# Altium schematic sheet 04_GAP9_CHIP_MEM.SchDoc
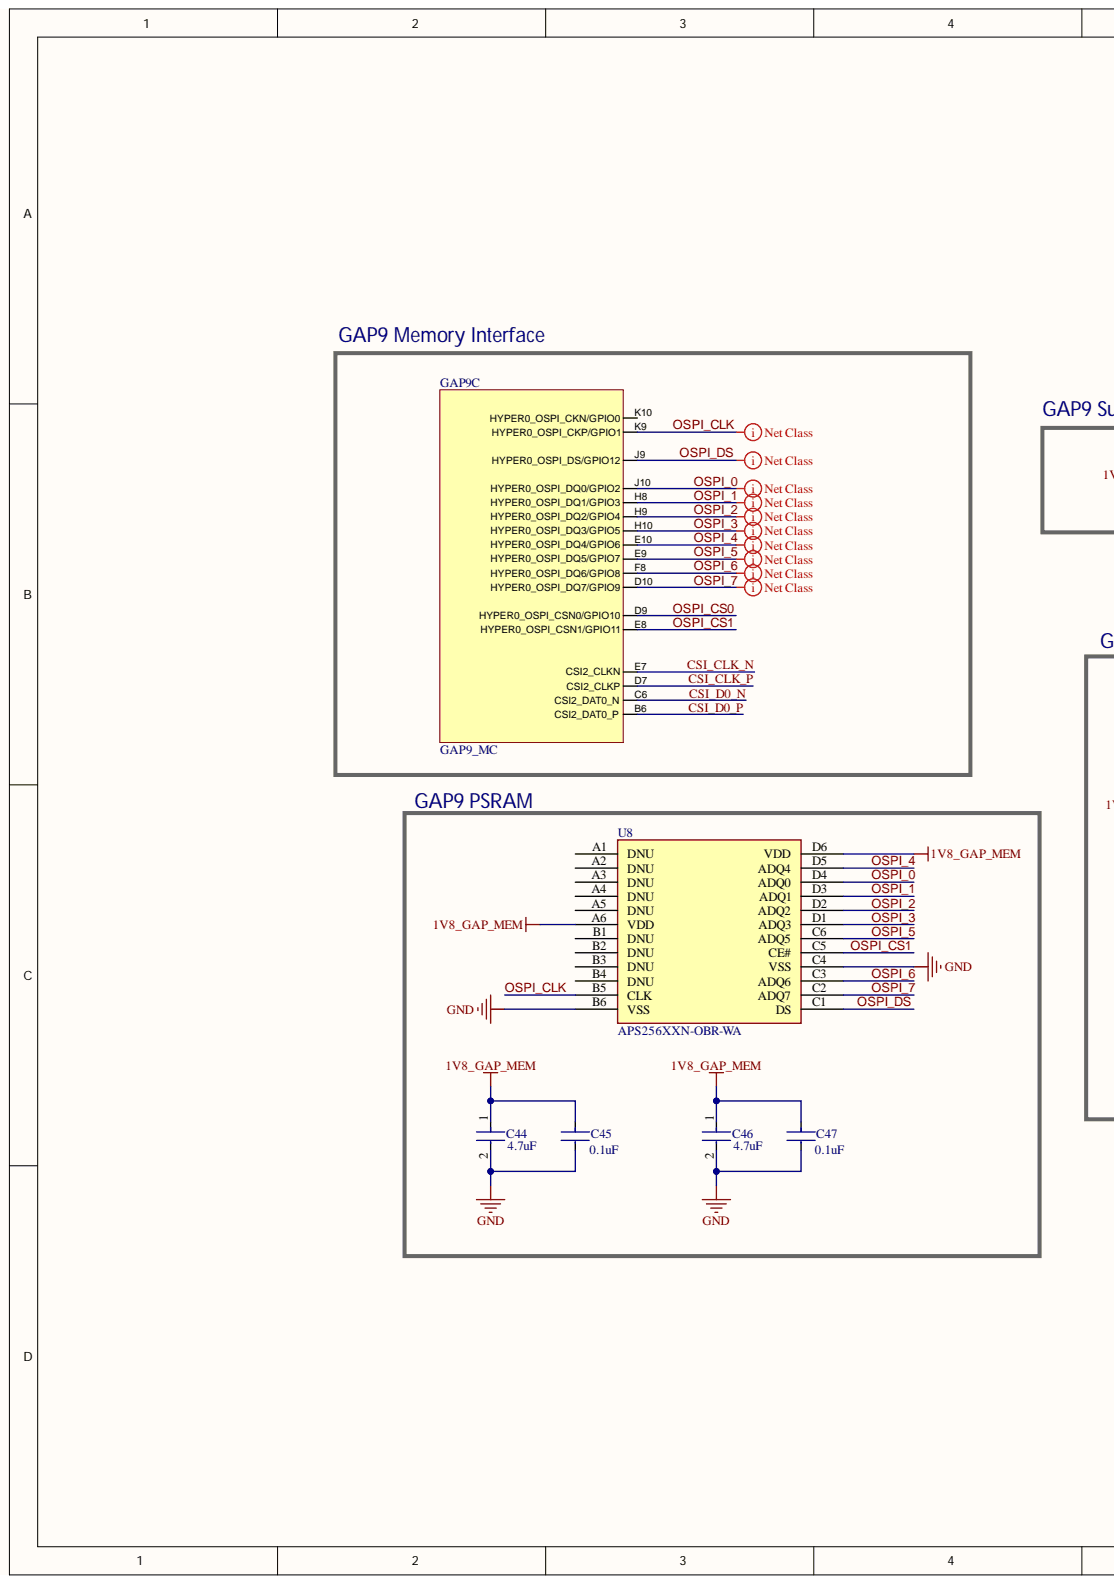
[diagram p0: 04_GAP9_CHIP_MEM.SchDoc, left]
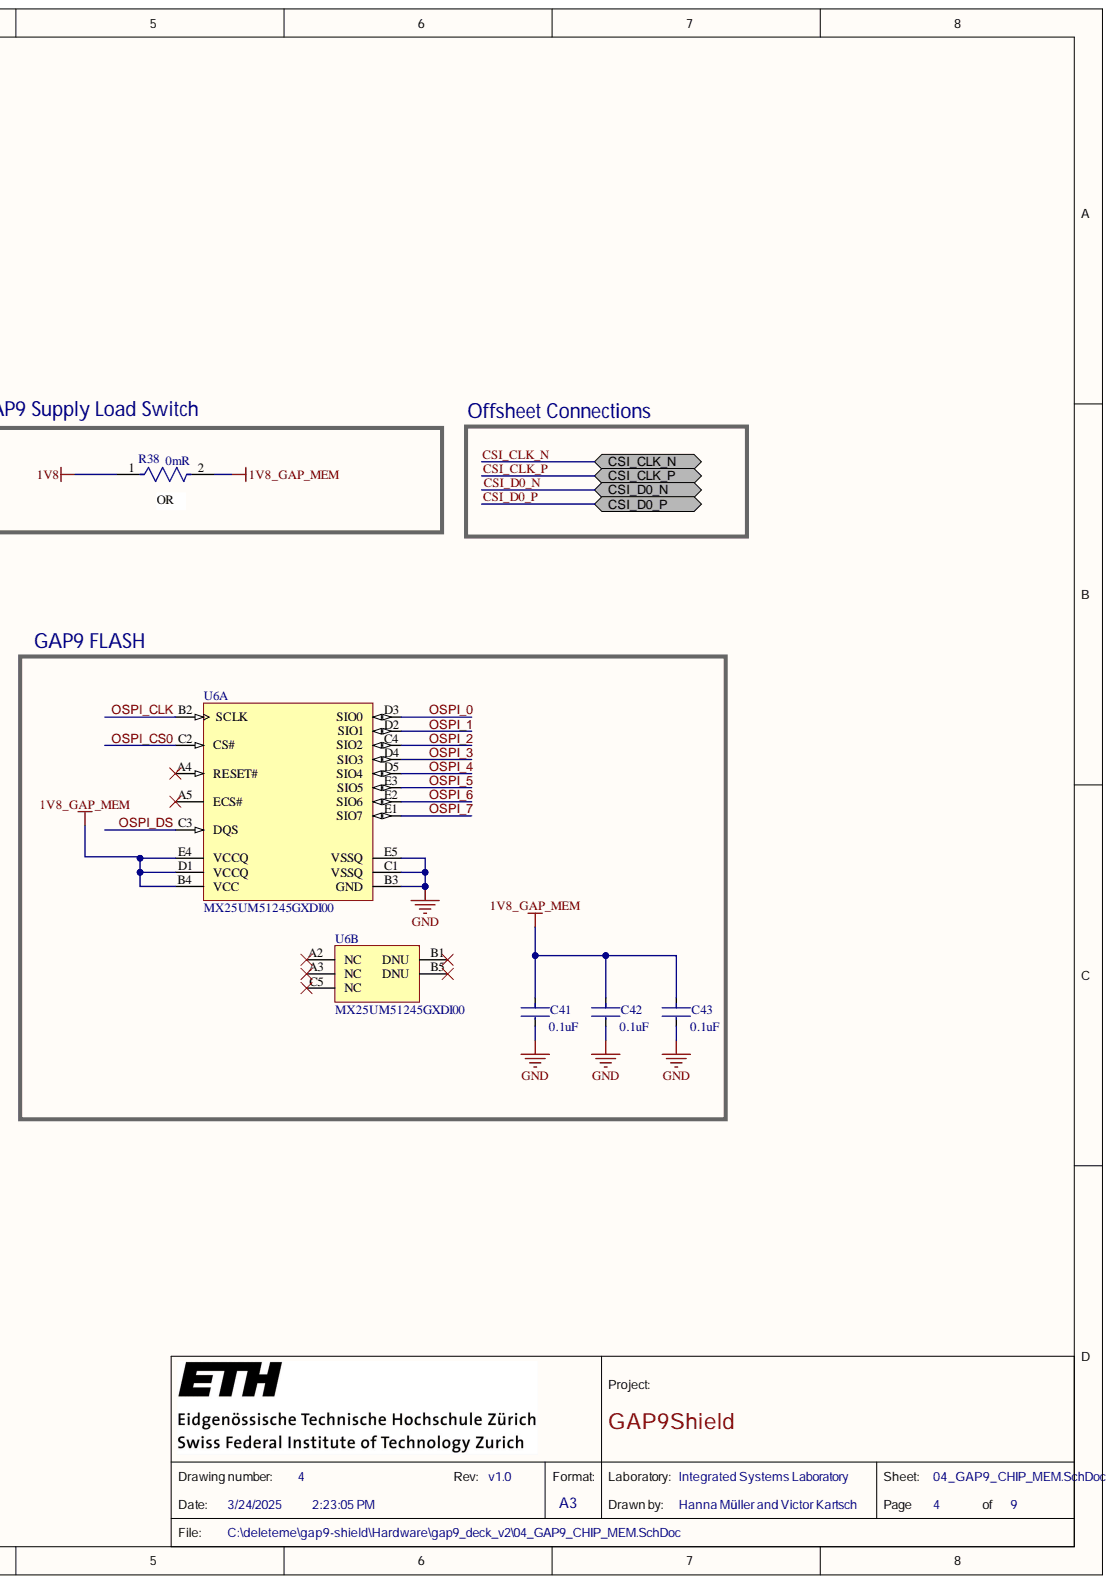
[diagram p1: 04_GAP9_CHIP_MEM.SchDoc, right]

## Parts
C41  value 0.1uF  Capacitance: 0.1uF  [FP-GRM033-0_03-IPC_B]  (hidden comment: GRM033Z71C104KE14D)
C42  value 0.1uF  Capacitance: 0.1uF  [FP-GRM033-0_03-IPC_B]  (hidden comment: GRM033Z71C104KE14D)
C43  value 0.1uF  Capacitance: 0.1uF  [FP-GRM033-0_03-IPC_B]  (hidden comment: GRM033Z71C104KE14D)
C44  value 4.7uF  Capacitance: 4.7uF  [FP-GRM035-0_09-IPC_B]  (hidden comment: GRM035R60J475ME15D)
C45  value 0.1uF  Capacitance: 0.1uF  [FP-GRM033-0_03-IPC_B]  (hidden comment: GRM033Z71C104KE14D)
C46  value 4.7uF  Capacitance: 4.7uF  [FP-GRM035-0_09-IPC_B]  (hidden comment: GRM035R60J475ME15D)
C47  value 0.1uF  Capacitance: 0.1uF  [FP-GRM033-0_03-IPC_B]  (hidden comment: GRM033Z71C104KE14D)
GAP9  GAP9_MC  [GAP9]  (part C)
R38  value 0mR  Resistance: 0mR  [FP-CRCW0402-e3-IPC_C]  (hidden comment: CRCW04020000Z0ED)
U6  MX25UM51245GXDI00  [FBGA24_A-130]  (parts A,B)
U8  APS256XXN-OBR-WA  [WLCSP24]

## Ports
CSI_CLK_N, CSI_CLK_P, CSI_D0_N, CSI_D0_P

## Nets
1V8: R38.1
1V8_GAP_MEM: C41.1 C42.1 C43.1 C44.1 C45.1 C46.1 C47.1 R38.2 U6.B4(VCC) U6.D1(VCCQ) U6.E4(VCCQ) U8.A6(VDD) U8.D6(VDD)
GND: C41.2 C42.2 C43.2 C44.2 C45.2 C46.2 C47.2 U6.B3(GND) U6.C1(VSSQ) U6.E5(VSSQ) U8.B6(VSS) U8.C4(VSS)
CSI_CLK_N: GAP9.E7(CSI2_CLKN) ; port CSI_CLK_N
CSI_CLK_P: GAP9.D7(CSI2_CLKP) ; port CSI_CLK_P
CSI_D0_N: GAP9.C6(CSI2_DAT0_N) ; port CSI_D0_N
CSI_D0_P: GAP9.B6(CSI2_DAT0_P) ; port CSI_D0_P
OSPI_0: GAP9.J10(HYPER0_OSPI_DQ0/GPIO2) U6.D3(SIO0) U8.D4(ADQ0)
OSPI_1: GAP9.H8(HYPER0_OSPI_DQ1/GPIO3) U6.D2(SIO1) U8.D3(ADQ1)
OSPI_2: GAP9.H9(HYPER0_OSPI_DQ2/GPIO4) U6.C4(SIO2) U8.D2(ADQ2)
OSPI_3: GAP9.H10(HYPER0_OSPI_DQ3/GPIO5) U6.D4(SIO3) U8.D1(ADQ3)
OSPI_4: GAP9.E10(HYPER0_OSPI_DQ4/GPIO6) U6.D5(SIO4) U8.D5(ADQ4)
OSPI_5: GAP9.E9(HYPER0_OSPI_DQ5/GPIO7) U6.E3(SIO5) U8.C6(ADQ5)
OSPI_6: GAP9.F8(HYPER0_OSPI_DQ6/GPIO8) U6.E2(SIO6) U8.C3(ADQ6)
OSPI_7: GAP9.D10(HYPER0_OSPI_DQ7/GPIO9) U6.E1(SIO7) U8.C2(ADQ7)
OSPI_CLK: GAP9.K9(HYPER0_OSPI_CKP/GPIO1) U6.B2(SCLK) U8.B5(CLK)
OSPI_CS0: GAP9.D9(HYPER0_OSPI_CSN0/GPIO10) U6.C2(CS#)
OSPI_CS1: GAP9.E8(HYPER0_OSPI_CSN1/GPIO11) U8.C5(CE#)
OSPI_DS: GAP9.J9(HYPER0_OSPI_DS/GPIO12) U6.C3(DQS) U8.C1(DS)

Not connected: GAP9 K10(HYPER0_OSPI_CKN/GPIO0); U6 A2(NC) A3(NC) A4(RESET#) A5(ECS#) B1(DNU) B5(DNU) C5(NC); U8 A1(DNU) A2(DNU) A3(DNU) A4(DNU) A5(DNU) B1(DNU) B2(DNU) B3(DNU) B4(DNU)

## Library data of the parts
C41-C43 C45 C47 = CMP-06035-035508-1: Chip Multilayer Ceramic Capacitors for General Purpose, 0201, 0.10uF, X7R, 15%, 10%, 16V; Case/Package: 0201; Component Kind: Standard; Library Name: Altium Content Vault
C44 C46 = CMP-06035-019701-1: Chip Multilayer Ceramic Capacitors for General Purpose, 0201, 4.7uF, X5R, 15%, 20%, 6.3V; Case Code (Imperial): 0201; Case Code (Metric): 0603; Case/Package: 0201; Component Kind: Standard; Dielectric: X5R; Length: 0.024inch; Library Name: Altium Content Vault; Max Operating Temperature: 85°C; Min Operating Temperature: -55°C; Mount: Surface Mount; Packaging: Tape and Reel; Thickness: 0.022inch; Tolerance: 20%; Voltage Rating: 6.3V; Voltage Rating (DC): 6.3V; Width: 0.012inch
R38 = CMP-2002-08122-2: RES Thick Film, 0Ω, 0.063W, 0402; Max Power Dissipation: 63mW; Temperature Coefficient: 100ppm/°C; Tolerance: 5%; Voltage Rating: 50V; Case Code (Imperial): 0402; Case Code (Metric): 1005; Case/Package: 0402; Component Kind: Standard; Composition: Thick Film; Contact Plating: Tin; Depth: 0.5mm; Features: Automotive AEC-Q200; Height: 0.35mm; Lead Free: Lead Free; Length: 1mm; Library Name: Altium Content Vault; Max Operating Temperature: 155°C; Military Standard: Not; Min Operating Temperature: -55°C; Mount: Surface Mount; Number of Terminations: 2; Package Quantity: 1; Packaging: Tape and Reel; Power Rating: 63mW; Radiation Hardening: No; Termination: SMD/SMT; Voltage Rating (DC): 50V; Weight: 2.3E-05oz; Width: 0.5mm
U6 = CMP-02792-1: NOR Flash Memory 512Mb 1.8V Octa I/O Serial 24-Pin BGA; Mfr: Macronix; Clock Frequency: 200 MHz; Memory Interface: SPI - Quad I/O, DTR; Memory Organization: 64M x 8; Memory Size: 512Mbit; Memory Type: Non-Volatile; Voltage - Supply: 1.65V ~ 2V; Write Cycle Time - Word, Page: 60µs, 750µs; Base Product Number: MX25UM51245; Component Kind: Standard; Digi-Key Programmable: Not Verified; Library Name: ETHZ Vault; Memory Format: FLASH; Mounting Type: Surface Mount; Operating Temperature: -40°C ~ 85°C (TA); Package / Case: 24-TBGA; Series: MXSMIO™; Supplier Device Package: 24-CSPBGA (6x8); Technology: FLASH - NOR
U8 = APS256XXN-OBR: No Description Available; Manufacturer_Part_Number: APS256XXN-OBR-BG; Mfr_Name: AP Memory; Component Kind: Standard; Library Name: EEG_SchLib.SchLib; RefDes: RefDes; Type: DEV; Copyright: Copyright (C) 2022 Ultra Librarian. All rights reserved.
GAP9 = GAP9_MC: Component Kind: Standard; Library Name: EEG_SchLib.SchLib
